# Altium schematic sheet POWERSP_SCH.SchDoc
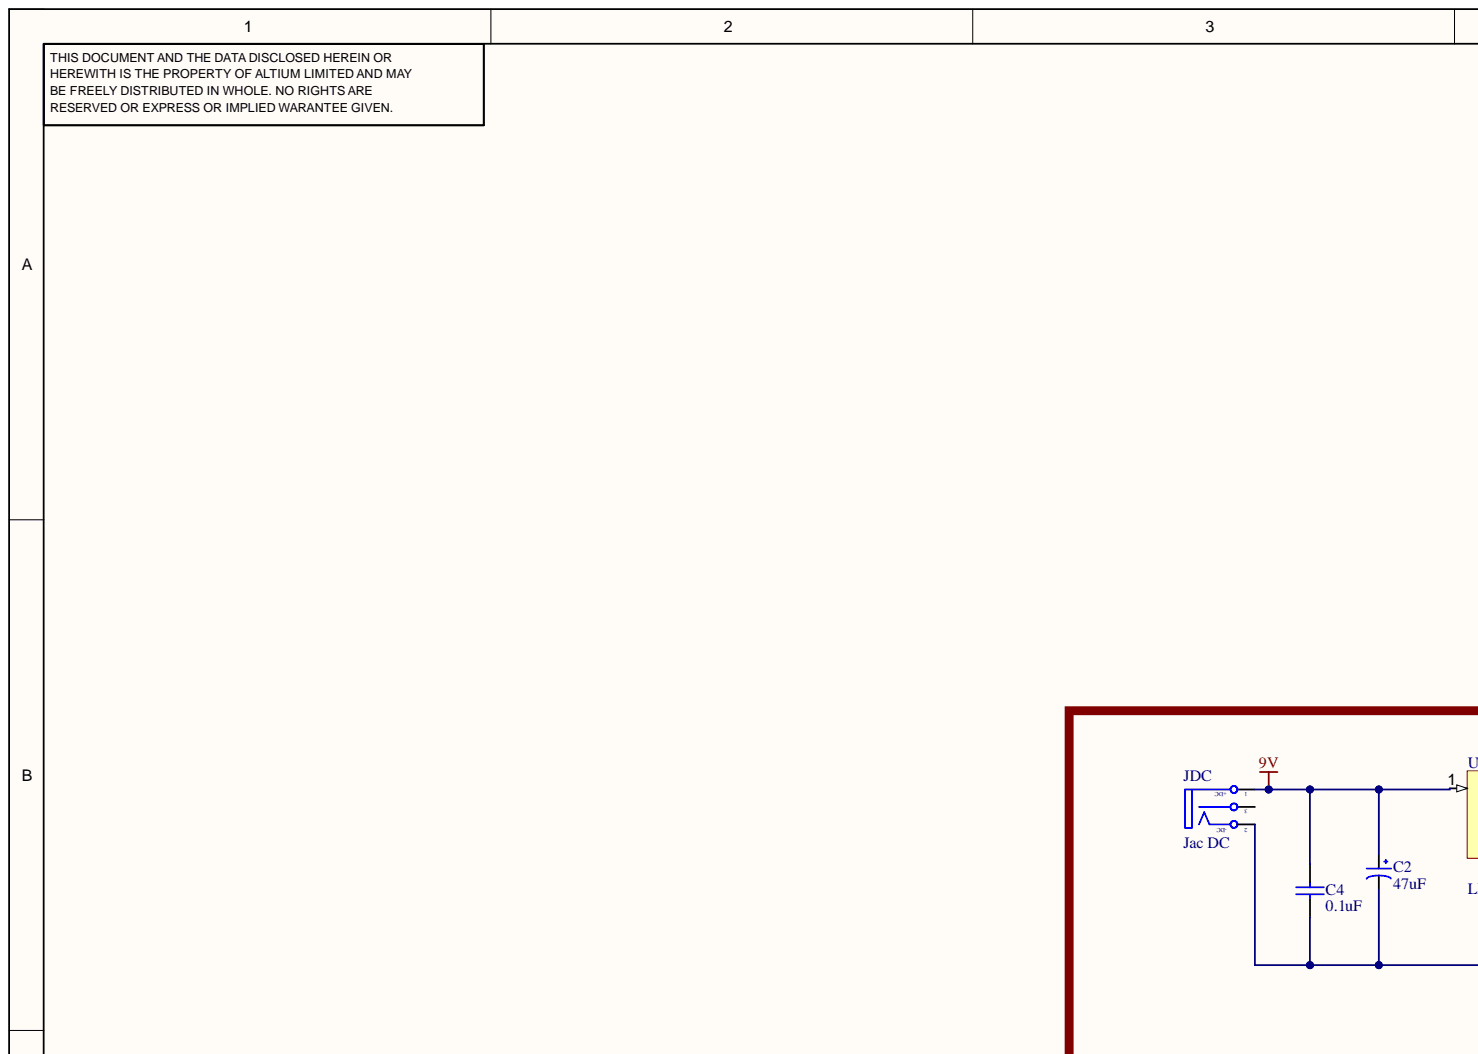
[diagram p0: POWERSP_SCH.SchDoc, top left]
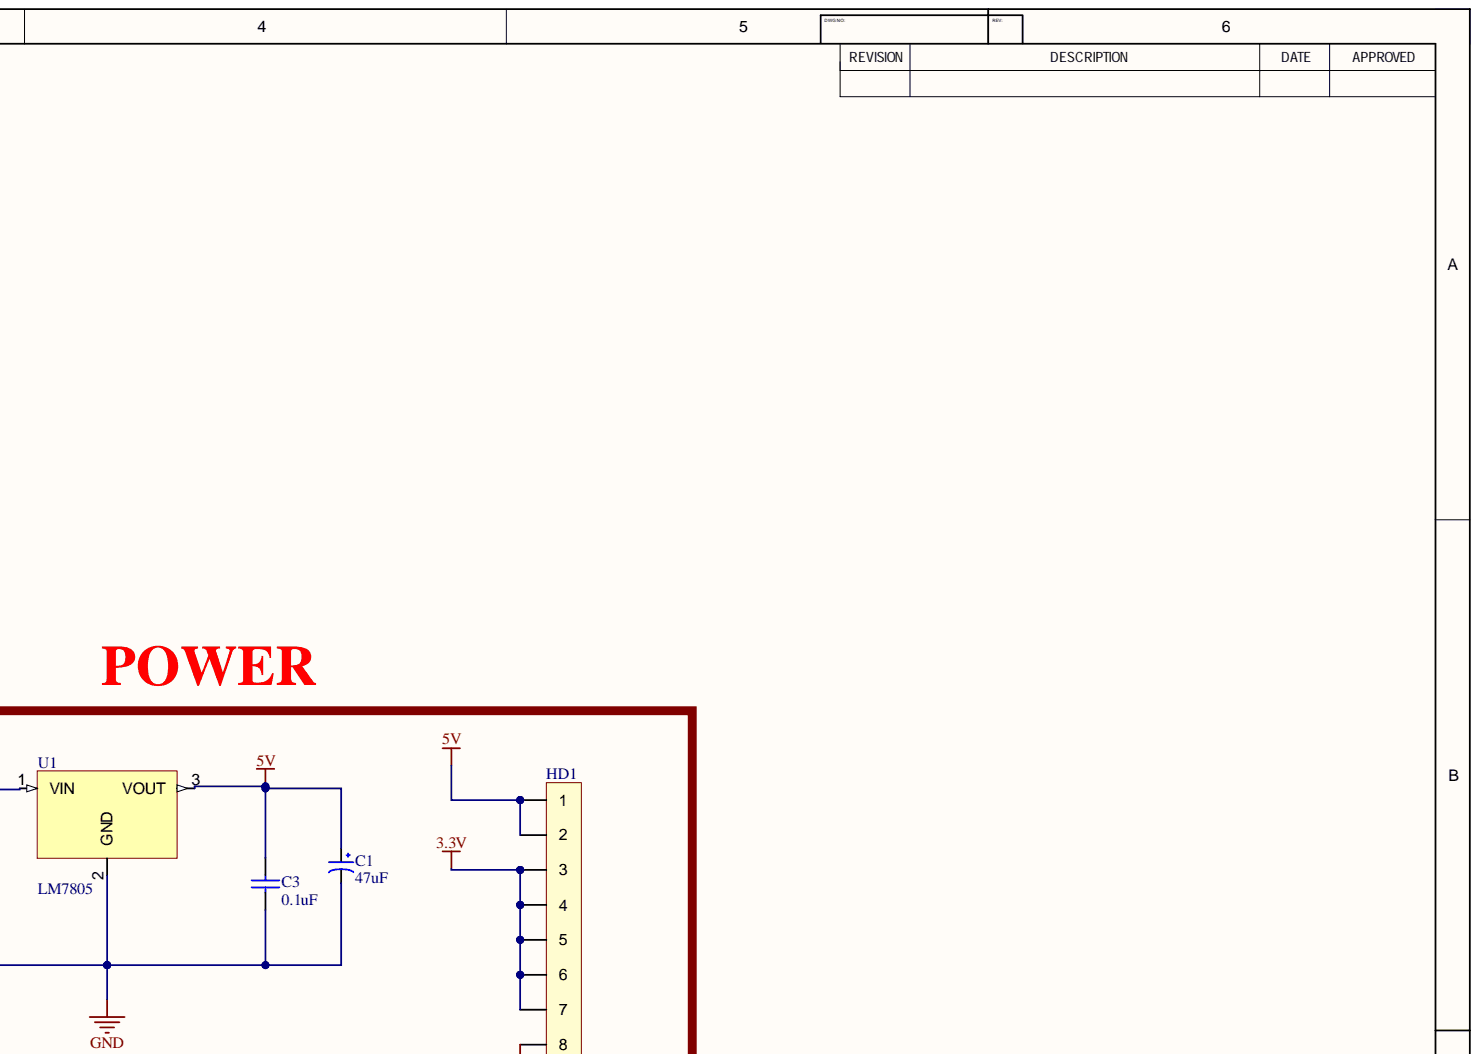
[diagram p1: POWERSP_SCH.SchDoc, top right]
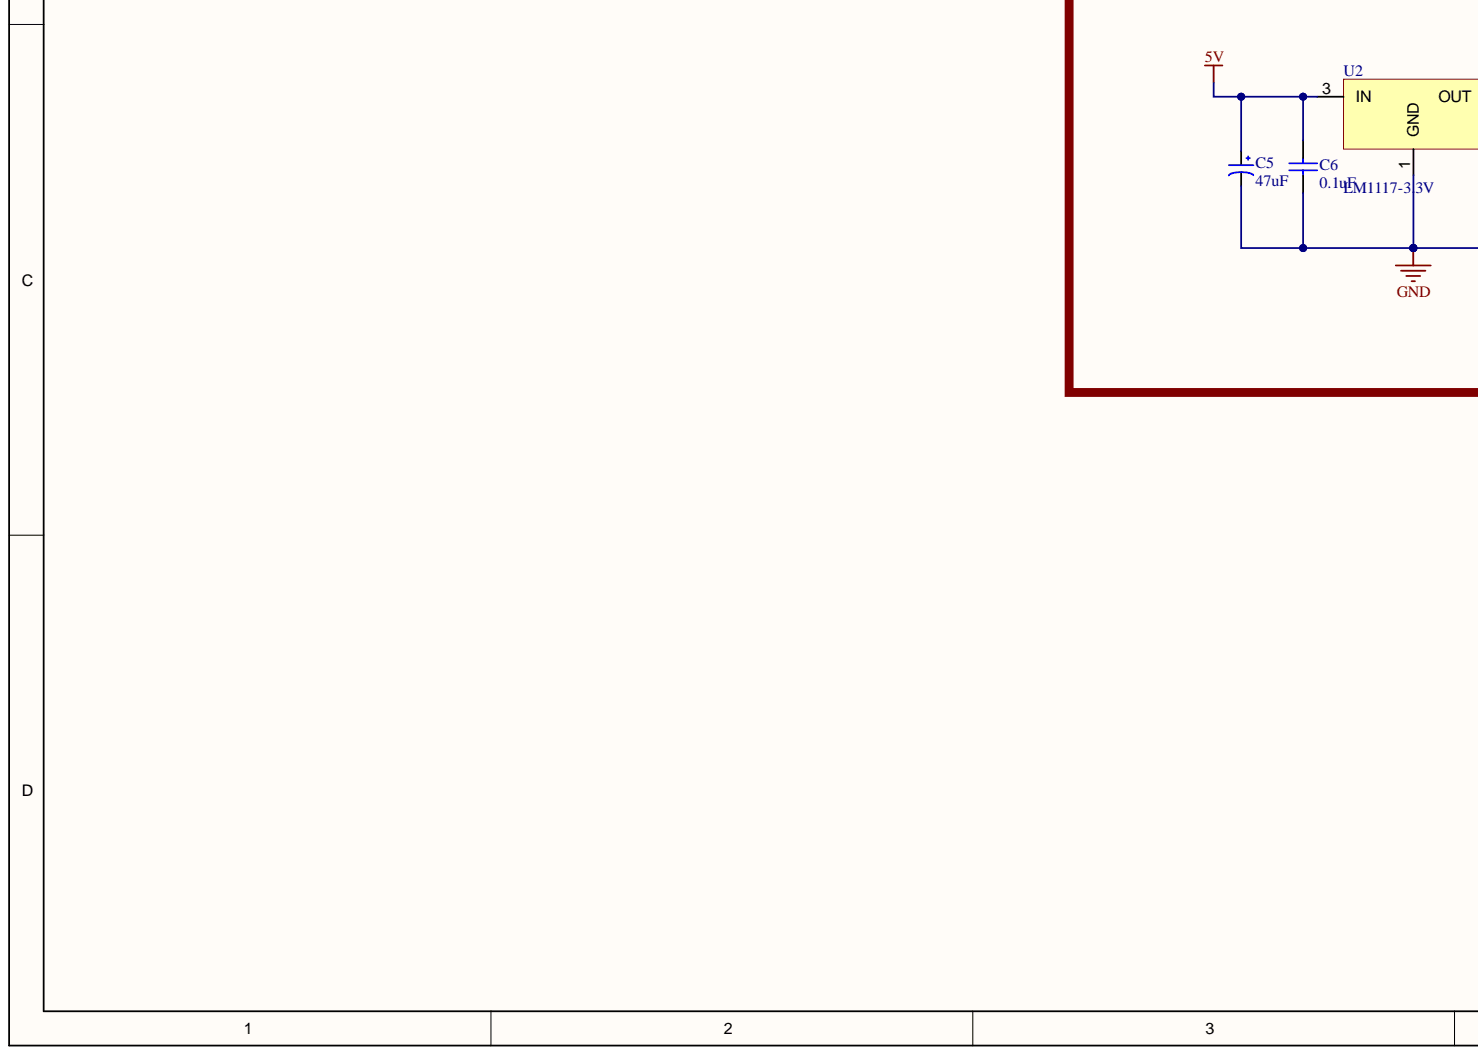
[diagram p2: POWERSP_SCH.SchDoc, bottom left]
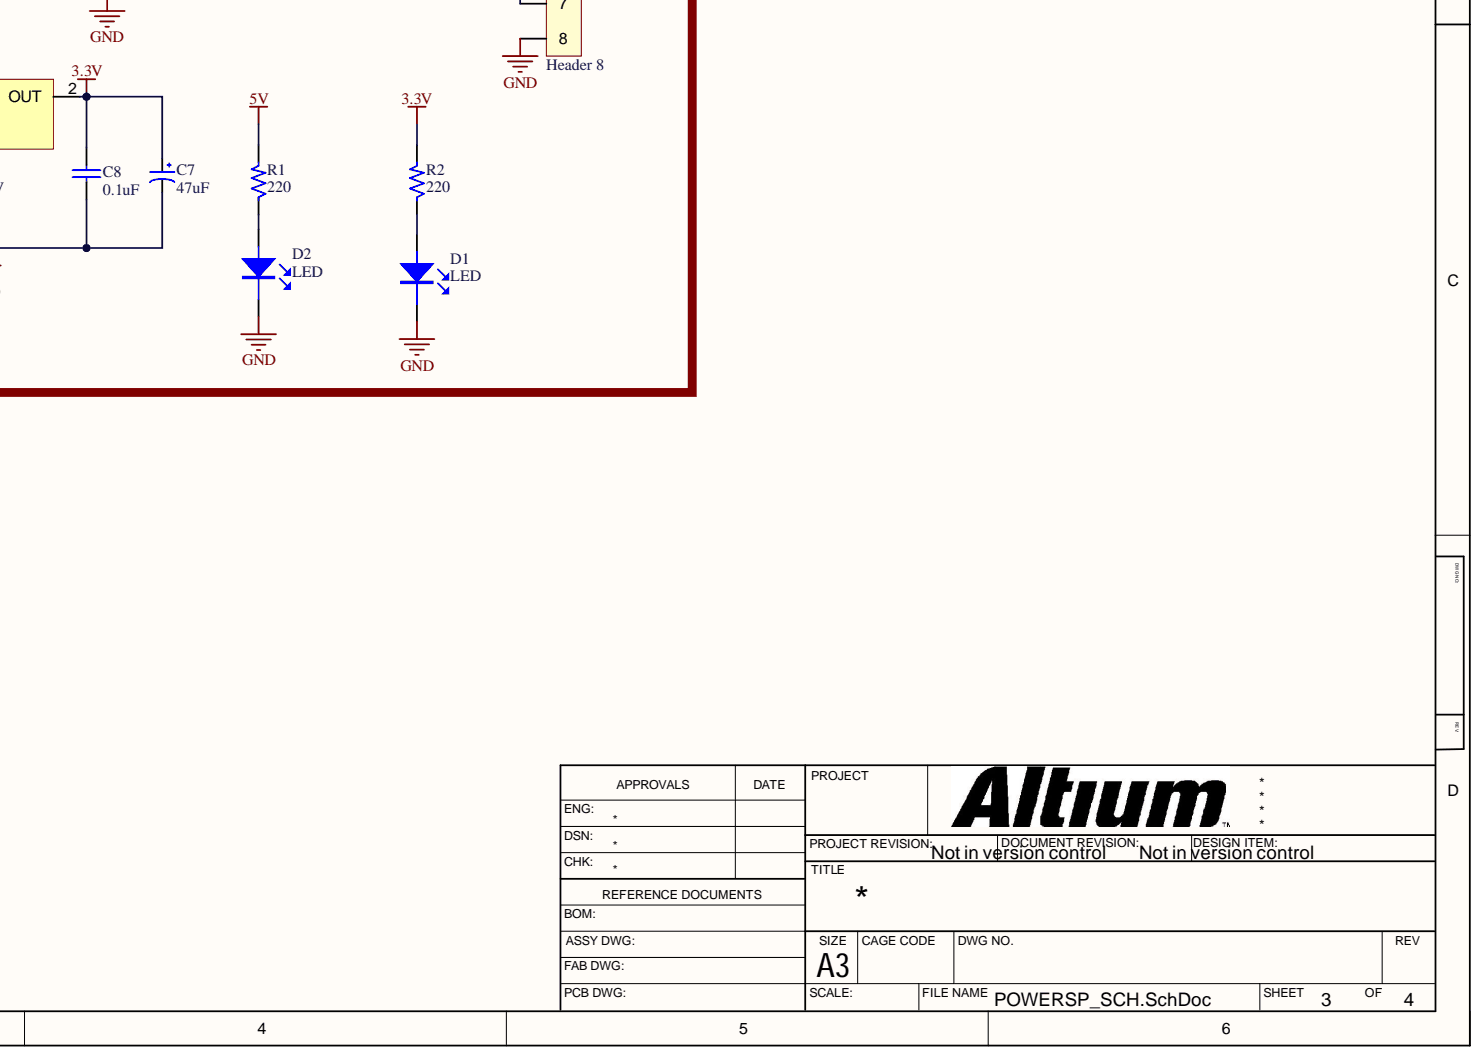
[diagram p3: POWERSP_SCH.SchDoc, bottom right]

## Parts
C1  47uF  [CP1/2]
C2  47uF  [CP1/2]
C3  0.1uF  [CN3]
C4  0.1uF  [CN3]
C5  47uF  [CP1/2]
C6  0.1uF  [CN3]
C7  47uF  [CP1/2]
C8  0.1uF  [CN3]
D1  LED  [CAP10UF]
D2  LED  [CAP10UF]
HD1  Header 8  [HED8V]
JDC  Jac DC  [DC-IN]
R1  220  [R1/6W]
R2  220  [R1/6W]
U1  LM7805  [TO-220]
U2  LM1117-3.3V  [SOT223-4N]

## Nets
3.3V: C7.1 C8.2 HD1.3 HD1.4 HD1.5 HD1.6 HD1.7 R2.2 U2.2
5V: C1.1 C3.2 C5.1 C6.2 HD1.1 HD1.2 R1.2 U1.3 U2.3
9V: C2.1 C4.2 JDC.1 U1.1
GND: C1.2 C2.2 C3.1 C4.1 C5.2 C6.1 C7.2 C8.1 D1.2 D2.2 HD1.8 JDC.2 U1.2 U2.1
NetD1_1: D1.1 R2.1
NetD2_1: D2.1 R1.1

Not connected: JDC 3

## Library data of the parts
C1 C2 C5 C7 = CP1: Cap P  0,1uF < 22uF , 16 - 50V; Description 1: 10 => 220uF/6,3V/10V - 10uF => 100uF/16V; Description 2: 0,1uF => 33uF/50V - 10uF => 22uF/63V; Description 3: 0,47uF => 10uF/100V; Value: 10uF/50V; Component Kind: Standard
  C2 C5 C7: Library Name: Cty1.SchLib
  C1: Library Name: syhaunguyen.SchLib
C3 C4 C6 C8 = CAP 102 2KV: Capacitor (Semiconductor SIM Model); Component Kind: Standard; LatestRevisionDate: 17-Jul-2002; LatestRevisionNote: Re-released for DXP Platform.; Library Name: Cty1.SchLib; PackageReference: C1206; Published: 8-Jun-2000; Signal Integrity: Cap Semi; Simulation: CAP; Value: 0.1uF; Publisher: Altium Limited
HD1 = Header 8: Header 8 Vit Broad; Component Kind: Standard; Library Name: Cty1.SchLib
JDC = DCIN: Jac DC input; Component Kind: Standard; Library Name: Cty1.SchLib; Value: Jac DC
R1 R2 = Res: Resistor 1/4W; Value: R1/4W - 1K; Component Kind: Standard; Library Name: Cty1.SchLib
U1 = LM7805: TO-220; Text Field1: 70; Text Field2: 30; Component Kind: Standard; Library Name: Cty1.SchLib
U2 = LM1117: 800mA Low-Dropout Linear Regulator; Component Kind: Standard; Library Name: Cty1.SchLib; Website: http://group3i.net; Creator: Nguyen Ba Bien
D1 D2 = LED: Component Kind: Standard; Library Name: Cty1.SchLib
